# Altium schematic sheet Connectors.SchDoc
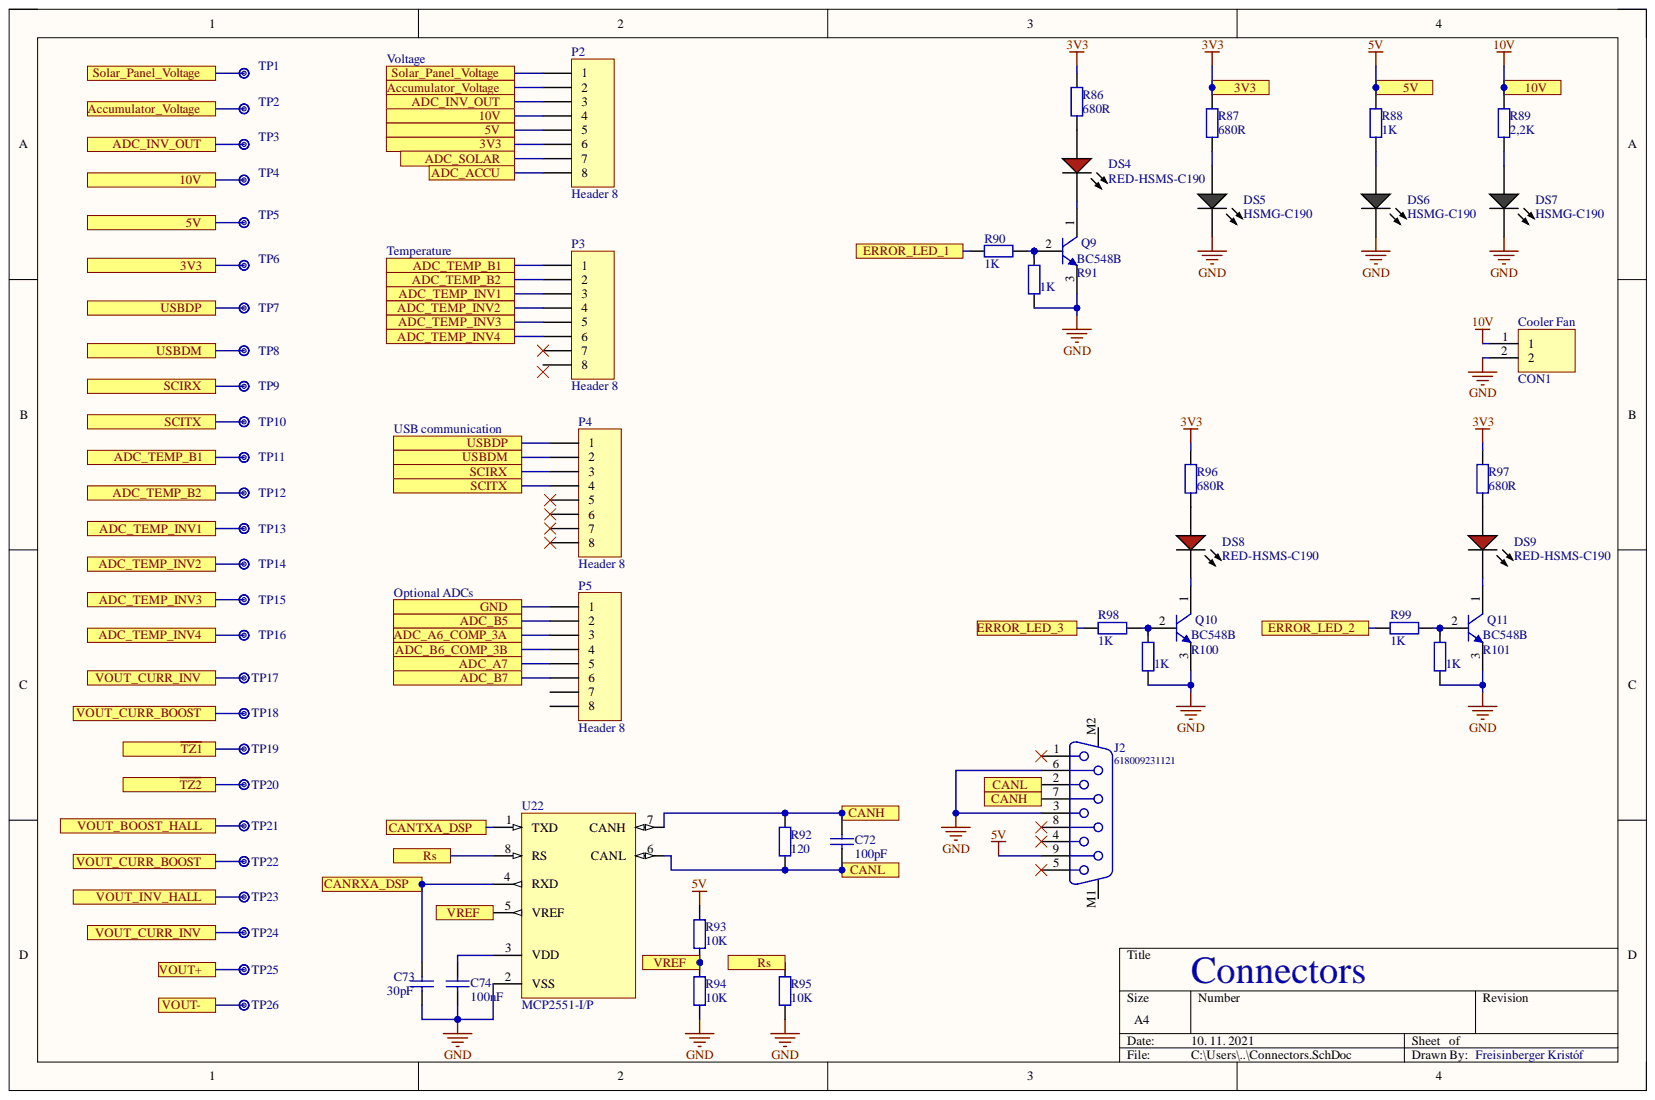
[diagram p0: Connectors.SchDoc]

## Parts
C72  100pF  [FP_0805_CAP_085]
C73  30pF  [FP_0805_CAP_085]
C74  100nF  [FP_0805_CAP_085]
CON1  Connector  [FP_CONN2_TAP_254MM_90]
DS4  RED-HSMS-C190  [AVAG-HSMX-C190_V]
DS5  HSMG-C190  [AVAG-HSMX-C190_V]
DS6  HSMG-C190  [AVAG-HSMX-C190_V]
DS7  HSMG-C190  [AVAG-HSMX-C190_V]
DS8  RED-HSMS-C190  [AVAG-HSMX-C190_V]
DS9  RED-HSMS-C190  [AVAG-HSMX-C190_V]
J2  618009231121  [618009231121]
P2  Header 8  [HDR1X8]
P3  Header 8  [HDR1X8]
P4  Header 8  [HDR1X8]
P5  Header 8  [HDR1X8]
Q9  BC548B  [ONSC-TO-92-3-29-11_AM_BL]
Q10  BC548B  [ONSC-TO-92-3-29-11_AM_BL]
Q11  BC548B  [ONSC-TO-92-3-29-11_AM_BL]
R86  680R  [FP_0603_RES]
R87  680R  [FP_0603_RES]
R88  1K  [FP_0603_RES]
R89  2,2K  [FP_0603_RES]
R90  1K  [FP_0603_RES]
R91  1K  [FP_0603_RES]
R92  120  [FP_0603_RES]
R93  10K  [FP_0603_RES]
R94  10K  [FP_0603_RES]
R95  10K  [FP_0603_RES]
R96  680R  [FP_0603_RES]
R97  680R  [FP_0603_RES]
R98  1K  [FP_0603_RES]
R99  1K  [FP_0603_RES]
R100  1K  [FP_0603_RES]
R101  1K  [FP_0603_RES]
TP1  TestPoint  [Test_Point]  (value not drawn)  (no BOM)
TP2  TestPoint  [Test_Point]  (value not drawn)  (no BOM)
TP3  TestPoint  [Test_Point]  (value not drawn)  (no BOM)
TP4  TestPoint  [Test_Point]  (value not drawn)  (no BOM)
TP5  TestPoint  [Test_Point]  (value not drawn)  (no BOM)
TP6  TestPoint  [Test_Point]  (value not drawn)  (no BOM)
TP7  TestPoint  [Test_Point]  (value not drawn)  (no BOM)
TP8  TestPoint  [Test_Point]  (value not drawn)  (no BOM)
TP9  TestPoint  [Test_Point]  (value not drawn)  (no BOM)
TP10  TestPoint  [Test_Point]  (value not drawn)  (no BOM)
TP11  TestPoint  [Test_Point]  (value not drawn)  (no BOM)
TP12  TestPoint  [Test_Point]  (value not drawn)  (no BOM)
TP13  TestPoint  [Test_Point]  (value not drawn)  (no BOM)
TP14  TestPoint  [Test_Point]  (value not drawn)  (no BOM)
TP15  TestPoint  [Test_Point]  (value not drawn)  (no BOM)
TP16  TestPoint  [Test_Point]  (value not drawn)  (no BOM)
TP17  TestPoint  [Test_Point]  (value not drawn)  (no BOM)
TP18  TestPoint  [Test_Point]  (value not drawn)  (no BOM)
TP19  TestPoint  [Test_Point]  (value not drawn)  (no BOM)
TP20  TestPoint  [Test_Point]  (value not drawn)  (no BOM)
TP21  TestPoint  [Test_Point]  (value not drawn)  (no BOM)
TP22  TestPoint  [Test_Point]  (value not drawn)  (no BOM)
TP23  TestPoint  [Test_Point]  (value not drawn)  (no BOM)
TP24  TestPoint  [Test_Point]  (value not drawn)  (no BOM)
TP25  TestPoint  [Test_Point]  (value not drawn)  (no BOM)
TP26  TestPoint  [Test_Point]  (value not drawn)  (no BOM)
U22  MCP2551-I/P  [PDIP300-P8]

## Ports
3V3, 5V, 10V, Accumulator_Voltage, ADC_A6_COMP_3A, ADC_A7, ADC_ACCU, ADC_B5, ADC_B6_COMP_3B, ADC_B7, ADC_INV_OUT, ADC_SOLAR, ADC_TEMP_B1, ADC_TEMP_B2, ADC_TEMP_INV1, ADC_TEMP_INV2, ADC_TEMP_INV3, ADC_TEMP_INV4, CANH, CANL, CANRXA_DSP, CANTXA_DSP, ERROR_LED_1, ERROR_LED_2, ERROR_LED_3, GND, Rs, SCIRX, SCITX, Solar_Panel_Voltage, USBDM, USBDP, VOUT+, VOUT-, VOUT_BOOST_HALL, VOUT_CURR_BOOST, VOUT_CURR_INV, VOUT_INV_HALL, VREF, ~{TZ1}, ~{TZ2}

## Nets
GND: C73.1 C74.1 CON1.2 DS5.2 DS6.2 DS7.2 J2.3 J2.6 Q9.3 Q10.3 Q11.3 R91.1 R94.1 R95.1 R100.1 R101.1 U22.2(VSS)
3V3: P2.6 R86.2 R87.2 R96.2 R97.2 TP6.1 ; port 3V3
5V: J2.9 P2.5 R88.2 R93.2 TP5.1 ; port 5V
10V: CON1.1 P2.4 R89.2 TP4.1 ; port 10V
Accumulator_Voltage: P2.2 TP2.1 ; port Accumulator_Voltage
ADC_A6_COMP_3A: P5.3 ; port ADC_A6_COMP_3A
ADC_A7: P5.5 ; port ADC_A7
ADC_ACCU: P2.8 ; port ADC_ACCU
ADC_B5: P5.2 ; port ADC_B5
ADC_B6_COMP_3B: P5.4 ; port ADC_B6_COMP_3B
ADC_B7: P5.6 ; port ADC_B7
ADC_INV_OUT: P2.3 TP3.1 ; port ADC_INV_OUT
ADC_SOLAR: P2.7 ; port ADC_SOLAR
ADC_TEMP_B1: P3.1 TP11.1 ; port ADC_TEMP_B1
ADC_TEMP_B2: P3.2 TP12.1 ; port ADC_TEMP_B2
ADC_TEMP_INV1: P3.3 TP13.1 ; port ADC_TEMP_INV1
ADC_TEMP_INV2: P3.4 TP14.1 ; port ADC_TEMP_INV2
ADC_TEMP_INV3: P3.5 TP15.1 ; port ADC_TEMP_INV3
ADC_TEMP_INV4: P3.6 TP16.1 ; port ADC_TEMP_INV4
CANH: C72.2 J2.7 R92.2 U22.7(CANH) ; port CANH
CANL: C72.1 J2.2 R92.1 U22.6(CANL) ; port CANL
CANRXA_DSP: C73.2 U22.4(RXD) ; port CANRXA_DSP
CANTXA_DSP: U22.1(TXD) ; port CANTXA_DSP
ERROR_LED_1: R90.1 ; port ERROR_LED_1
ERROR_LED_2: R99.1 ; port ERROR_LED_2
ERROR_LED_3: R98.1 ; port ERROR_LED_3
Rs: R95.2 U22.8(RS) ; port Rs
SCIRX: P4.3 TP9.1 ; port SCIRX
SCITX: P4.4 TP10.1 ; port SCITX
Solar_Panel_Voltage: P2.1 TP1.1 ; port Solar_Panel_Voltage
~{TZ1}: TP19.1 ; port ~{TZ1}
~{TZ2}: TP20.1 ; port ~{TZ2}
USBDM: P4.2 TP8.1 ; port USBDM
USBDP: P4.1 TP7.1 ; port USBDP
VOUT_BOOST_HALL: TP21.1 ; port VOUT_BOOST_HALL
VOUT_CURR_BOOST: TP18.1 TP22.1 ; port VOUT_CURR_BOOST
VOUT_CURR_INV: TP17.1 TP24.1 ; port VOUT_CURR_INV
VOUT_INV_HALL: TP23.1 ; port VOUT_INV_HALL
VREF: R93.1 R94.2 U22.5(VREF) ; port VREF
NetC74_2: C74.2 U22.3(VDD)
NetDS4_1: DS4.1 R86.1
NetDS4_2: DS4.2 Q9.1
NetDS5_1: DS5.1 R87.1
NetDS6_1: DS6.1 R88.1
NetDS7_1: DS7.1 R89.1
NetDS8_1: DS8.1 R96.1
NetDS8_2: DS8.2 Q10.1
NetDS9_1: DS9.1 R97.1
NetDS9_2: DS9.2 Q11.1
NetP5_1: P5.1 ; port GND
NetQ9_2: Q9.2 R90.2 R91.2
NetQ10_2: Q10.2 R98.2 R100.2
NetQ11_2: Q11.2 R99.2 R101.2
NetTP25_1: TP25.1
NetTP26_1: TP26.1

Not connected: J2 1 4 5 8 M1 M2; P3 7 8; P4 5 6 7 8; P5 7 8

## Library data of the parts
C72-C74 = CAP: Capacitor; Component Kind: Standard; Library Name: DCDC_Converter_4_Channel.SchLib
  C72: Value: 100pF
  C73: Value: 30pF
  C74: Value: 100nF
CON1 = Connector_2pin: 2pin connector; Reference: 100.240.80; Component Kind: Standard; Supplier: hestore; Library Name: RobonAUT_2021feb.SchLib
DS4 DS8 DS9 = RED-CMP-2000-06427-1: LED Uni-Color Red, 52 mW, 20 mA, -40 to 85 degC, 2-Pin SMD, RoHS, Tape and Reel; Manufacturer: Avago; Chip Material: GaP; DELETED: Compliant; Dominant Wave Length: 626nm; Forward Current: 25mA; Forward Voltage: 2.6V; High Brightness: No; Lens Type: ColorlessDiffused; Lum Intensity: 10mcd; Main Category: ChipLED; Number of  Elements: 1; Peak Wavelength: 630nm; Power Dissipation: 52W; Product Depth (mm): 0.8mm; Product Length (mm): 1.6mm; Reverse Voltage: 5V; Shape Type: Rectangular; Test Current (It): 20mA; Viewing Angle: 170deg; Component Kind: Standard; Datasheet URL: http://docs.avagotech.com/docs/AV02-0551EN; Datasheet Version: 03/2012; Emitting Color: Red; Lens Dimensions: 1x0.8x0.5mm; Library Name: DCDC_Converter_4_Channel.SchLib; Luminous Intensity: 10mcd; Manufacturer URL: http://www.avagotech.com/; Mounting: SurfaceMount; Mounting Technology: SurfaceMount; Operating Temp Range: -40Cto85C; Operating Temperature Classification: Industrial; Package Description: 2-Pin Surface Mount Device, Body 1.6 x 0.8 mm, Height 0.9 mm; Package Reference: HSMX-C190; Package Type: ChipLed; Packaging: TapeandReel; Pin Count: 2; Product Height (mm): 0.8mm; Rad Hardened: No; Type: Uni-Color
DS5-DS7 = LED_G_CMP-1744-00002-1: Chip LED, Green, 2.2 V, -40 to 85 degC, 2-Pin SMD, RoHS, Tape and Reel; Access Time: 3500 ns; Case\Package: SOIC; Clock Frequency: 100 KHz; Density: 32 kb; Frequency: 400 KHz; Interface: Serial; Max Supply Voltage: 5.5 V; Min Supply Voltage: 1.7 V; Nominal Supply Current: 2 mA; Component Kind: Standard; ComponentLink1Description: Manufacturer URL; ComponentLink1URL: http://www.avagotech.com/; ComponentLink2Description: Datasheet; ComponentLink2URL: http://docs.avagotech.com/docs/AV02-0551EN; ComponentLink3Description: Package Specification; ComponentLink3URL: http://www.fairchildsemi.com/dwg/MA/MA03D.pdf; DatasheetVersion: Mar-12; Height: 1.5 mm; Length: 5 mm; Library Name: DCDC_Converter_4_Channel.SchLib; Max Clock Frequency: 100 KHz; Max Operating Temperature: 85 degC; Min Operating Temperature: -40 degC; Mount: Surface Mount; Package Quantity: 3000; PackageDescription: 2-Pin Surface Mount Device, Body 1.6 x 0.8 mm, Height 0.9 mm; PackageReference: HSMX-C190; Packaging: Tape and Reel; Pins: 8; Radiation Hardening: No; REACH SVHC: No SVHC; RoHSCompliant: true; Width: 4 mm
J2 = CMP-1502-00200-1: Female PCB Connector with Hex Screw WR-DSUB, 8.08 mm, Angled, 9 pins; Manufacturer: Wurth Elektronik; Contact Resistance: 30mOhm; Pin Number: 9; Rated Current: 3A; Working Voltage: 125VAC; Component Kind: Standard; Datasheet URL: https://katalog.we-online.de/em/datasheet/6180xx231121.pdf; Gender: Female; Library Name: Altium Content Vault; Manufacturer URL: http://www.we-online.de; Mounting Technology: THT; Operating Temperature: -40to85degC; Orientation: Angled; Package Reference: 618009231121; Packaging: Tray; Part Number: 618009231121; Publisher: WurthElektronik
P2-P5 = Header 8: Header, 8-Pin; Reference: 100.240.95; Component Kind: Standard; LatestRevisionDate: 17-Jul-2002; LatestRevisionNote: Re-released for DXP Platform.; Library Name: Miscellaneous Connectors.IntLib; Signal Integrity: Connector; Supplier: hestore; Publisher: Altium Limited
Q9-Q11 = CMP-1048-01603-1: Amplifier Transistor, NPN Silicon, 3-Pin TO-92, Pb-Free, Tape and Reel; Manufacturer: On Semiconductor; PartNumber: BC548BRL1G; fT Min (MHz): 150; IC Continuous (A): 0.1; Component Kind: Standard; ComponentLink1Description: Manufacturer URL; ComponentLink1URL: http://www.onsemi.com/; ComponentLink2Description: Datasheet; ComponentLink2URL: http://www.onsemi.com/pub_link/Collateral/BC546-D.PDF; ComponentLink3Description: Package Specification; ComponentLink3URL: http://www.onsemi.com/pub_link/Collateral/MAC97-D.PDF; DatasheetVersion: Rev. 7; Library Name: Altium Content Vault; Mounting Technology: Through Hole; PackageDescription: 3-Pin Transistor Outline, Body 4.825 x 3.685 mm, Pitch 1.27 mm; PackageReference: TO-92-3-29-11_AM_BL; PackageVersion: Rev. AM; Packing: Tape and Reel; Polarity: NPN; RoHS: TRUE
R86-R101 = RES: Resistor; Component Kind: Standard
  R86 R87 R96 R97: Library Name: DCDC_Converter_4_Channel.SchLib; Value: 680R
  R88: Library Name: DCDC_Converter_4_Channel.SchLib; Value: 1K
  R89: Library Name: DCDC_Converter_4_Channel.SchLib; Value: 2,2K
  R90 R91 R98-R101: Value: 1K; Library Name: Napelem_Inverter.SchLib
  R92: Library Name: DCDC_Converter_4_Channel.SchLib; Value: 120
  R93-R95: Library Name: DCDC_Converter_4_Channel.SchLib; Value: 10K
TP1-TP26 = TestPoint: Test Point; Library Name: Napelem_Inverter.SchLib
U22 = CMP-0186-00101-2: High-Speed CAN Transceiver, 8-Pin PDIP, Industrial Temperature; Code_JEDEC: MS-001; Component Kind: Standard; ComponentLink1Description: Manufacturer URL; ComponentLink1URL: http://www.microchip.com/; ComponentLink2Description: Datasheet; ComponentLink2URL: http://ww1.microchip.com/downloads/en/DeviceDoc/21667F.pdf; ComponentLink3Description: Package Specification; ComponentLink3URL: http://www.microchip.com/stellent/groups/techpub_sg/documents/packagingspec/en012702.pdf; DatasheetVersion: revF, Jul-2010; Library Name: Altium Content Vault; PackageDescription: 8-Lead Plastic Dual In-Line (P) - 300 mil Body [PDIP]; PackageReference: PDIP300-P8; PackageVersion: revBB, Aug-2009; RevisionNote: URLs added. DatasheetVersion updated.
